# Altium schematic sheet Power Supply.SchDoc
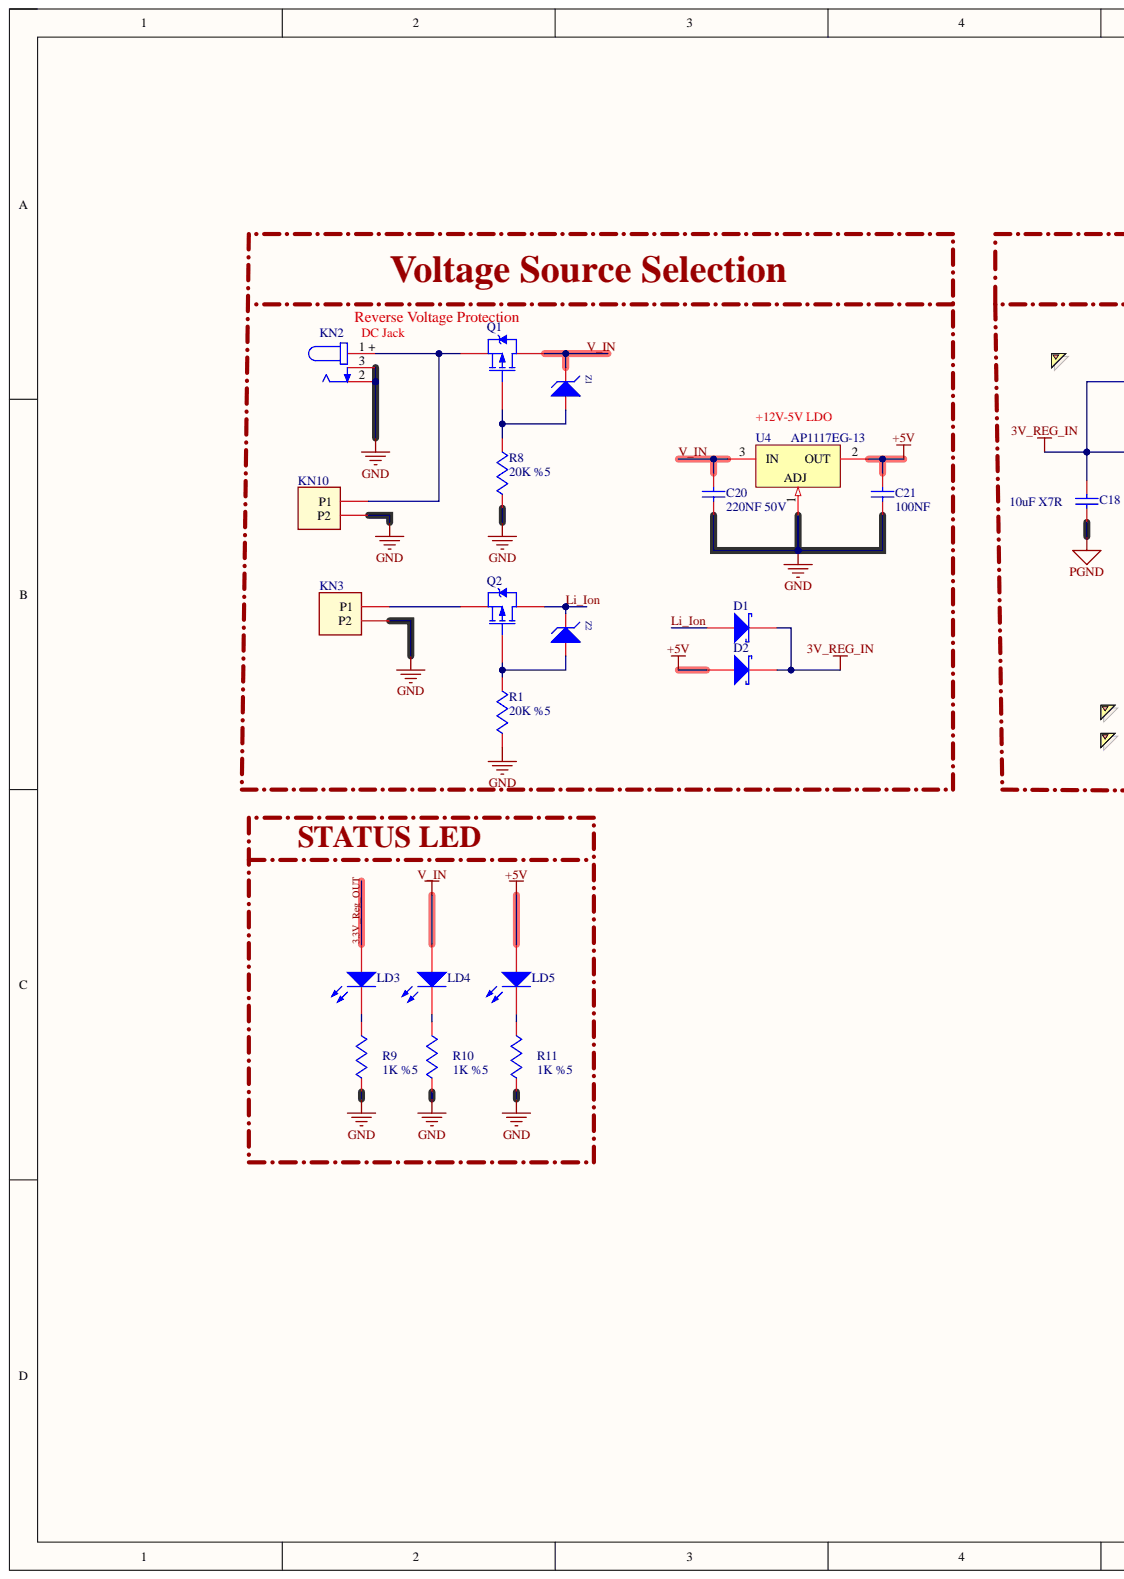
[diagram p0: Power Supply.SchDoc, left]
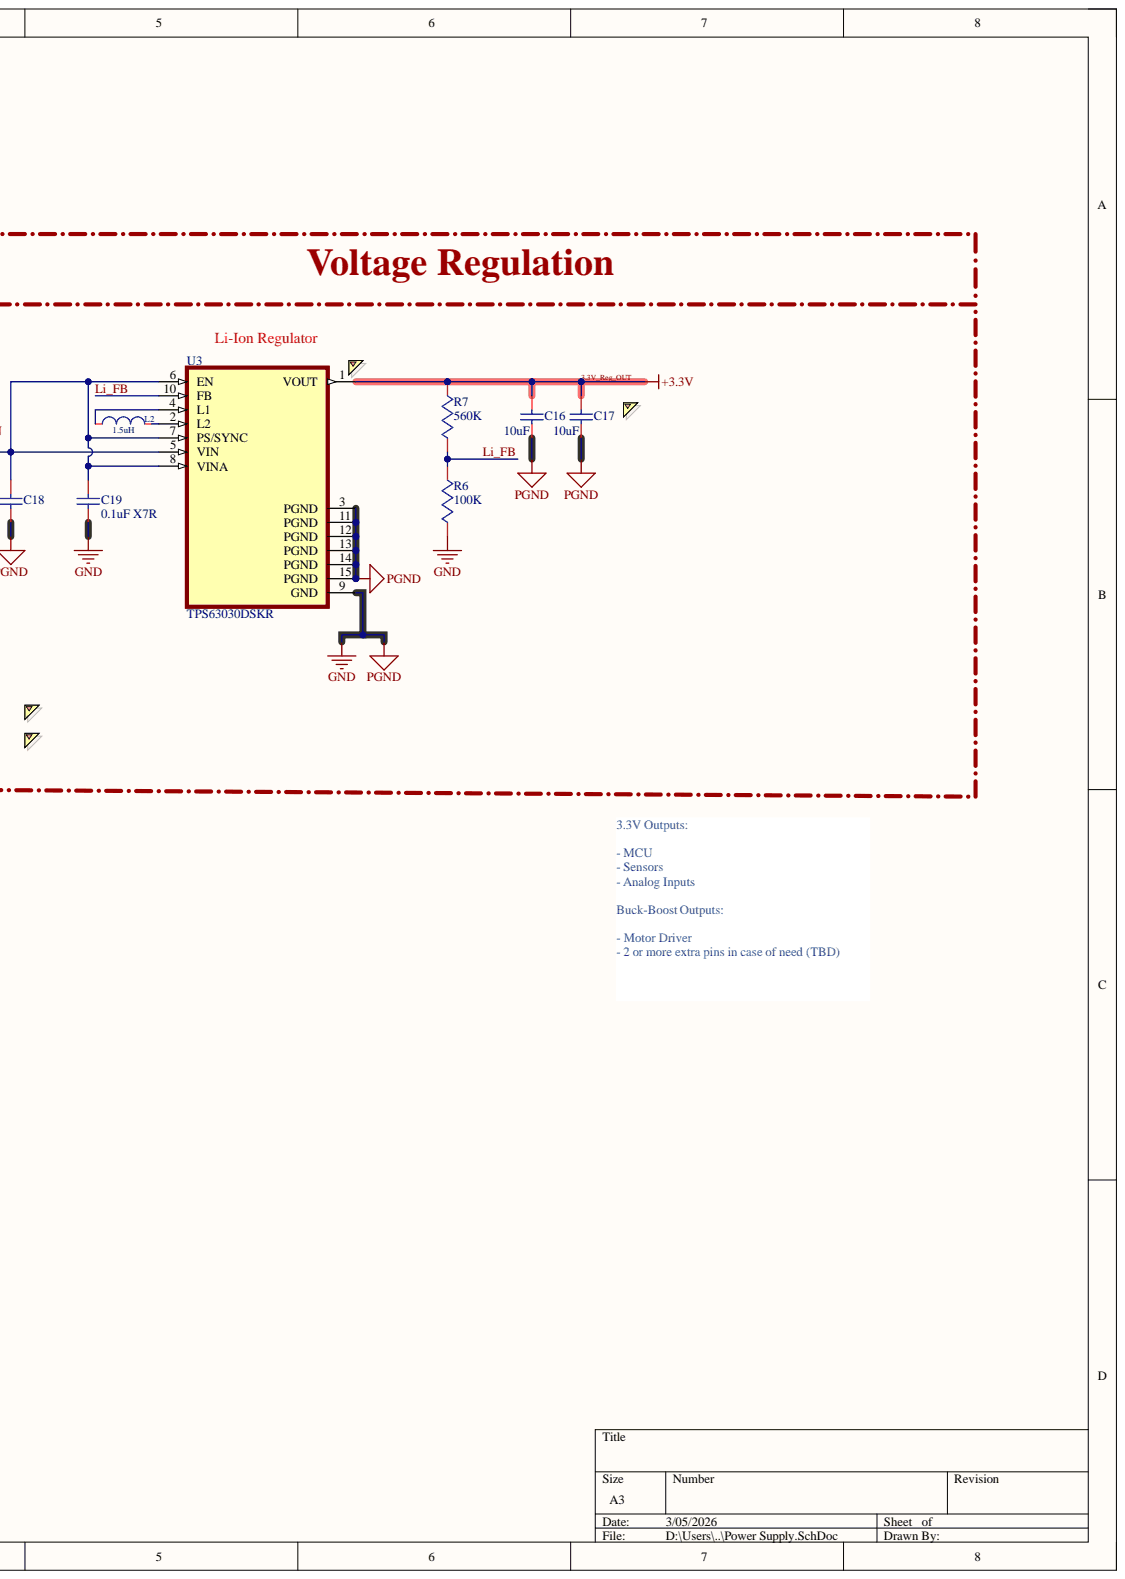
[diagram p1: Power Supply.SchDoc, right]

## Parts
C16  10uF  [C0603]
C17  10uF  [C0603]
C18  10uF X7R  [C0603]
C19  0.1uF X7R  [C0603]
C20  220NF 50V  [C0603]
C21  100NF  [C0603]
D1  SMD22PL MCC  [SOD123F]  (value not drawn)
D2  SMD22PL MCC  [SOD123F]  (value not drawn)
KN2  DC Jack  [POWER JACK]
KN3  2 Pin 2.54mm Header  [2-PIN Connector]  (value not drawn)
KN10  2 Pin 2.54mm Header  [2-PIN Connector]  (value not drawn)
L2  1.5uH  [LPH4018]
LD3  17-21/R6C-AP1Q2L/3T RED  [LED0805 RED]  (value not drawn)
LD4  17-21/R6C-AP1Q2L/3T RED  [LED0805 RED]  (value not drawn)
LD5  17-21/R6C-AP1Q2L/3T RED  [LED0805 RED]  (value not drawn)
Q1  BSS84 FAIR-DIODES  [SOT23]  (value not drawn)
Q2  BSS84 FAIR-DIODES  [SOT23]  (value not drawn)
R1  20K %5  [R0805]
R6  100K  [R0603]
R7  560K  [R0603]
R8  20K %5  [R0805]
R9  1K %5  [R0603]
R10  1K %5  [R0603]
R11  1K %5  [R0603]
U3  TPS63030DSKR  [SON50P250X250X80-11N]
U4  AP1117EG-13  [SOT223]
Z1  10V 200mW  [SOD323]  (value not drawn)
Z2  10V 200mW  [SOD323]  (value not drawn)

## Nets
3V_REG_IN: C18.2 C19.2 D1.1 D2.1 U3.5(VIN) U3.6(EN) U3.7(PS/SYNC) U3.8(VINA)
+5V: C21.2 D2.2 LD5.2 U4.2
GND: C16.1 C17.1 C18.1 C19.1 C20.1 C21.1 KN2.2 KN2.3 KN3.2 KN10.2 R1.1 R6.1 R8.1 R9.1 R10.1 R11.1 U3.3(PGND) U3.9(GND) U3.11(PGND) U3.12(PGND) U3.13(PGND) U3.14(PGND) U3.15(PGND) U4.1
+3.3V: C16.2 C17.2 LD3.2 R7.2 U3.1(VOUT)
Li_FB: R6.2 R7.1 U3.10(FB)
Li_Ion: D1.2 Q2.3 Z2.1
V_IN: C20.2 LD4.2 Q1.3 U4.3 Z1.1
NetKN2_1: KN2.1 KN10.1 Q1.2
NetKN3_1: KN3.1 Q2.2
NetL2_1: L2.1 U3.4(L1)
NetL2_2: L2.2 U3.2(L2)
NetLD3_1: LD3.1 R9.2
NetLD4_1: LD4.1 R10.2
NetLD5_1: LD5.1 R11.2
NetQ1_1: Q1.1 R8.2 Z1.2
NetQ2_1: Q2.1 R1.2 Z2.2

## Library data of the parts
C16 C17 = C0603 10UF 10V %20 X6S: Database Table Name: Capacitor SMD; Malzeme Tanımı: CAP 0603 CER 10u 10V%20 X6S; SapStokKodu: 1200920512; Siparis Kodu: 0603S106M100/EOL; ClassName: 3.3V_Regulator; Component Kind: Standard; Part Number: C0603 10UF 10V %20 X6S; Value: 10UF 10V; Library Name: OTL.DbLib
C18 = C0603 10UF 25V %10 X5R: Database Table Name: Capacitor SMD; Malzeme Tanımı: CAP 0603 CER 10u 25V %20 X5R; SapStokKodu: 1200920558; Siparis Kodu: 0603X/106M250NT; ClassName: 3.3V_Regulator; Component Kind: Standard; Part Number: C0603 10UF 25V %10 X5R; Value: 10UF 25V; Library Name: OTL.DbLib
C19 = 0603 10uF 50V%10 X7R FLEX: Database Table Name: Capacitor SMD; Defined by: MK; Malzeme Tanımı: CAP 0603 CER 10UF 50V%10 X7R FLEX; SapStokKodu: 1200920778; Siparis Kodu: SH18B106K500CT; ClassName: 3.3V_Regulator; Component Kind: Standard; Part Number: 0603 10uF 50V%10 X7R FLEX; Value: 10uF 50V; Library Name: OTL.DbLib
C20 = C0603 220NF 50V %10 X7R: Database Table Name: Capacitor SMD; Malzeme Tanımı: CAP 0603 CER 220n 50V%10 X7R; SapStokKodu: 1200920550; Siparis Kodu: CS1608X7R224K500NRB; ClassName: Buck_Converter; Component Kind: Standard; Part Number: C0603 220NF 50V %10 X7R; Value: 220NF 50V; Library Name: OTL.DbLib
C21 = C0603 100NF 25V %10 X7R: Database Table Name: Capacitor SMD; Malzeme Tanımı: CAP 0603 CER 100n 25V%10 X7R; SapStokKodu: 1200920254; Siparis Kodu: 06033C104KAT2A; ClassName: Buck_Converter; Component Kind: Standard; Part Number: C0603 100NF 25V %10 X7R; Value: 100NF 25V; Library Name: OTL.DbLib
D1 D2 = NRVTS260ESFT1G: Database Table Name: Diode General; Defined by: MK; Malzeme Tanımı: DIODE SCHOTTKY 60V 2A SOD123FL AG; SAPStokKodu: 1200560019; Siparis Kodu: NRVTS2H60ESFT1G; Component Kind: Standard; Part Number: SMD22PL; Value: NRVTS260ESFT1G; Library Name: OTL.DbLib
KN2 = PJ-102A: Database Table Name: Connector; Defined by: MK; Malzeme Tanımı: KONN. DC POWER JACK 2.0mm 2.5A; SAPStokKodu: 1200951323; Value: POWER JACK; Component Kind: Standard; Part Number: PJ-102A; Siparis Kodu: PJ-102A; Library Name: OTL.DbLib
KN3 KN10 = 22_03_5025: Database Table Name: Connector; Malzeme Tanımı: KONN SPOX 2LI ERKEK 2.50mm; SAPStokKodu: 1200950245; Siparis Kodu: YMW025-02R; Component Kind: Standard; Part Number: 22_03_5025; Value: 22_03_5025; Library Name: OTL.DbLib
L2 = LPH4018T 4u7H %20 1A7: Database Table Name: Inductor; Defined by: MK; Malzeme Tanımı: IND SMD 4.7uH 1.7A %20; SAPStokKodu: 1200940650; Siparis Kodu: CSME0418D-4R7M-LRH; ClassName: 3.3V_Regulator; Component Kind: Standard; Part Number: LPH4018T 4u7H %20 1A7; Value: 4u7H %20 1A7; Library Name: OTL.DbLib
LD3-LD5 = 17-21/R6C-AP1Q2L/3T RED: Database Table Name: Diode Led; Malzeme Tanımı: LED 0805 RED 45-112mcd 632nm AlInGaP; SAPStokKodu: 1200960277; Siparis Kodu: 17-21/R6C-AP1Q2L/TR8(ARC); Component Kind: Standard; Part Number: 17-21/R6C-AP1Q2L/3T RED; Value: 17-21/R6C-AP1Q2L/3T RED; Library Name: OTL.DbLib
Q1 Q2 = BSS84 FAIR-DIODES: P-Channel MOSFET; Database Table Name: Transistor; Defined by: MK; Malzeme Tanımı: TRANS PMOSFET SM50V 130mA Sot23 760mA; SAPStokKodu: 1200980898; ClassName: V_IN_Reverse_Volt_Prot; Component Kind: Standard; Part Number: BSS84 FAIR-DIODES; Siparis Kodu: BSS84; Value: BSS84 FAIR-DIODES; Library Name: OTL.DbLib
R1 R8 = R0805 20K %5 1/8W: Database Table Name: Resistor SMD; Malzeme Tanımı: RES 0805 20K %5 1/8W; SapStokKodu: 1200970239; Siparis Kodu: CRCW080520K0JNEA; ClassName: V_IN_Reverse_Volt_Prot; Component Kind: Standard; Part Number: R0805 20K %5 1/8W; Value: 20K %5; Library Name: OTL.DbLib
R6 = R0603 200K %1 1/10W: Database Table Name: Resistor SMD; Defined by: MK; Malzeme Tanımı: RES 0603 200K %1 1/10W; SapStokKodu: 1200971360; Siparis Kodu: CRCW0603200KFKEA; Uretici: ROYAL OHM; Value: 200K %1; ClassName: 3.3V_Regulator; Component Kind: Standard; Datasheet Link: https://www.mouser.com.tr/datasheet/2/1365/1-3044649.pdf; Part Number: R0603 200K %1 1/10W; Library Name: OTL.DbLib
R7 = R0603 560K %1 1/10W: Database Table Name: Resistor SMD; Defined by: MK; Value: 560K %1; ClassName: 3.3V_Regulator; Component Kind: Standard; Part Number: R0603 560K %1 1/10W; Library Name: OTL.DbLib
R9-R11 = R0603 1K %5 1/10W: Database Table Name: Resistor SMD; Malzeme Tanımı: RES 0603 1K %5 1/10W; SapStokKodu: 1200970522; Siparis Kodu: FCF03JT102; Component Kind: Standard; Part Number: R0603 1K %5 1/10W; Value: 1K %5; Library Name: OTL.DbLib
U3 = TPS63030DSKR: High Efficient Single Inductor Buck-Boost Converter with 1-A Switches; MF: Texas Instruments; Check_prices: https://www.snapeda.com/parts/TPS63030DSKR/Texas+Instruments/view-part/?ref=eda; ClassName: 3.3V_Regulator; Component Kind: Standard; Library Name: TPS63030DSKR.IntLib; MP: TPS63030DSKR; Package: WSON-10 Texas Instruments; SnapEDA_Link: https://www.snapeda.com/parts/TPS63030DSKR/Texas+Instruments/view-part/?ref=snap
U4 = AP1117EG-13: Database Table Name: IC Regulator; Component Kind: Standard; Part Number: AP1117EG-13; Value: AP1117EG-13; Library Name: OTL.DbLib
Z1 Z2 = BZT52B10S 10V 200mW: Database Table Name: Diode Zener; Defined by: MK; Malzeme Tanımı: DIODE ZENER SM 10V 200mW %2; SAPStokKodu: 1200981463; Uretici: GOODARK; ClassName: V_IN_Reverse_Volt_Prot; Component Kind: Standard; Datasheet Link: https://diotec.com/request/datasheet/mm3z2v4.pdf; Part Number: BZT52B10S 10V 200mW; Siparis Kodu: BZT52B10S; Value: 10V 200mW; Library Name: OTL.DbLib
